# Altium schematic sheet ADC.SchDoc(ADC)
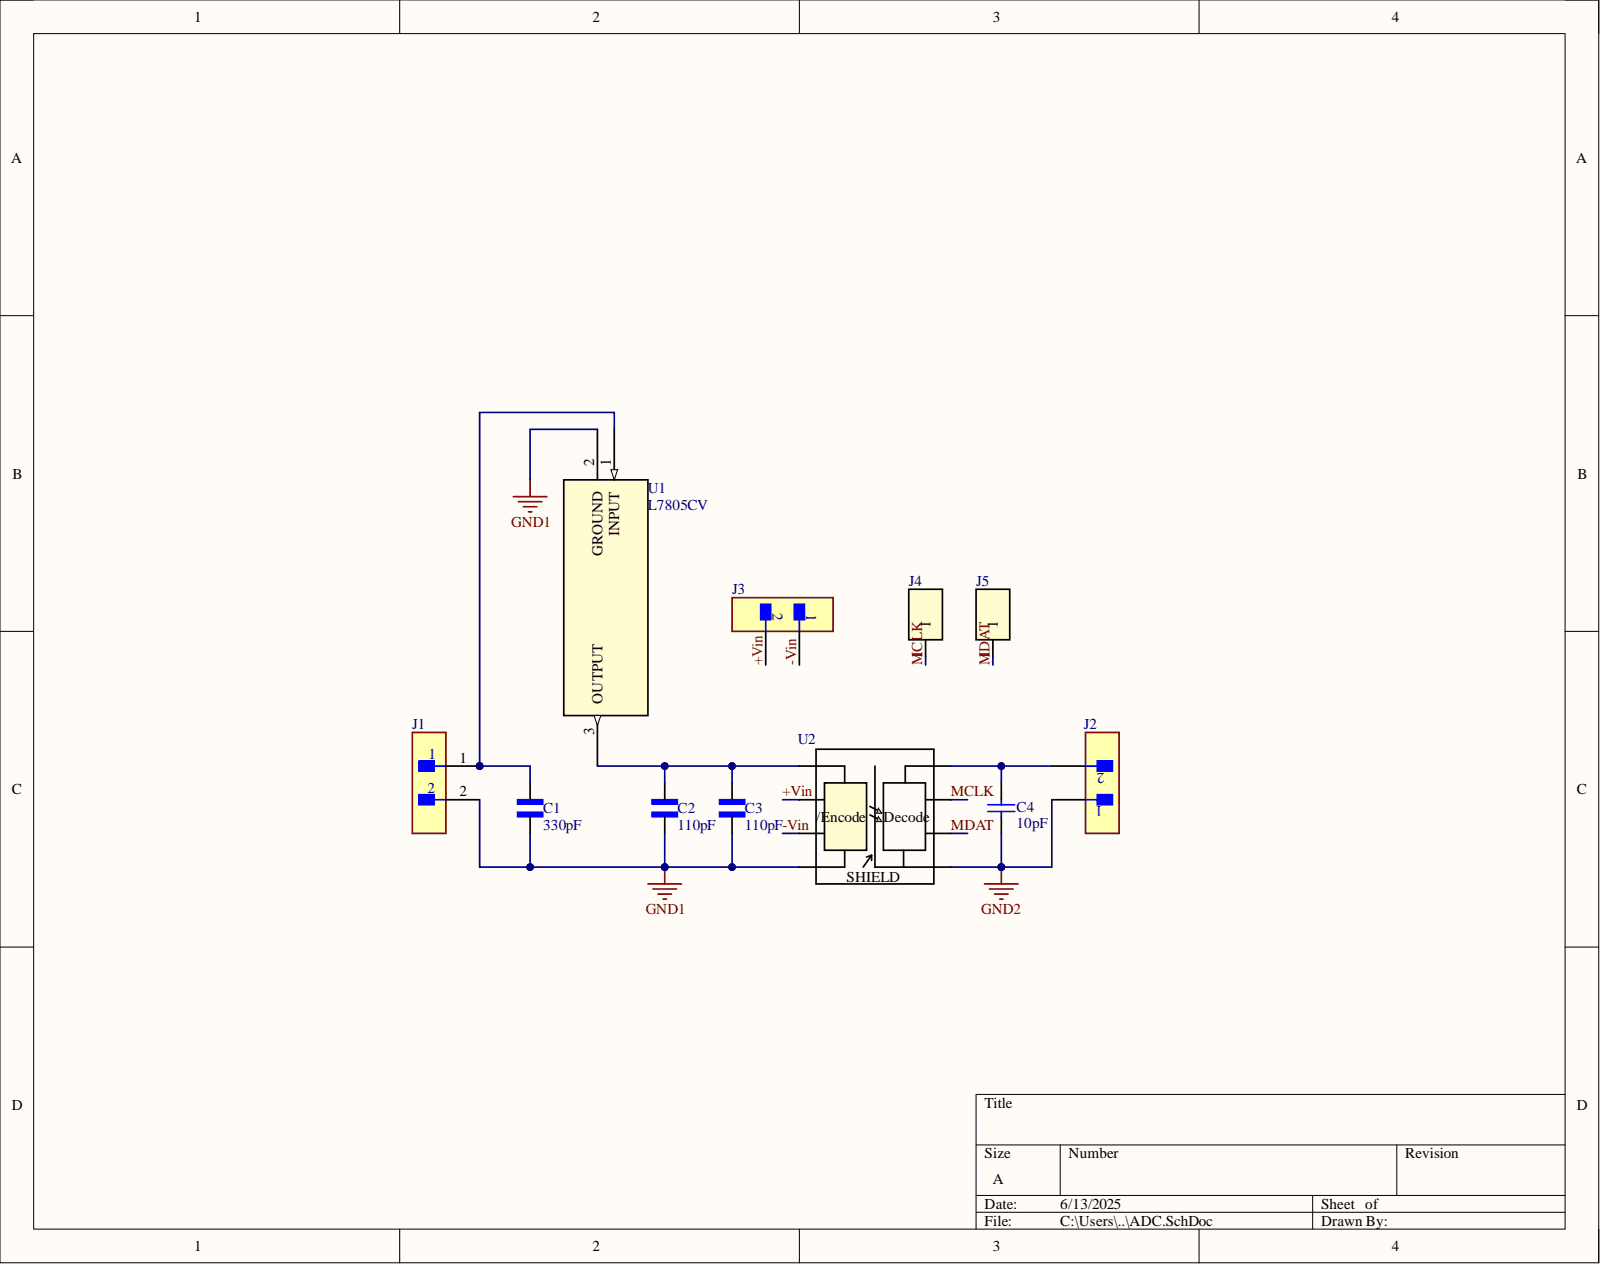
[diagram p0: ADC.SchDoc]

## Parts
C1  330pF  [CAP_ECHU1H331JX5]
C2  110pF  [CAP_ECHU1H101JX5]
C3  110pF  [CAP_ECHU1H101JX5]
C4  10pF  [CAPC0603X28X15LL05T05]
J1  M20-9990246  [FP-M20-9990246-MFG]  (value not drawn)
J2  M20-9990246  [FP-M20-9990246-MFG]  (value not drawn)
J3  M20-9990246  [FP-M20-9990246-MFG]  (value not drawn)
J4  87224-1  [87224-1_TYC]  (value not drawn)
J5  87224-1  [87224-1_TYC]  (value not drawn)
U1  L7805CV  [TO-220_STM]
U2  TLP7830TP4,E  [SO8L_TOS]  (value not drawn)

## Nets
GND1: C1.1 C2.1 C3.1 J1.2 U1.2 U2.4
GND2: C4.1 J2.2 U2.5
+Vin: J3.2 U2.2
-Vin: J3.1 U2.3
MCLK: J4.1 U2.7
MDAT: J5.1 U2.6
NetC1_2: C1.2 J1.1 U1.1
NetC2_2: C2.2 C3.2 U1.3 U2.1
NetC4_2: C4.2 J2.1 U2.8

## Library data of the parts
C1 = ECHU1H331JX5: Capacitor; ECHU, SMD, Stack Met PPS Film Cap, 50VDC, 0.00033uF, 5%, 0820, Cut Tape; Manufacturer: Panasonic; Manufacturer Part Number: ECH-U1H331JX5; Dielectric Material: Polyphenylene; Insulation Resistance: 3GR; Material: Ceramic; Voltage: 50V; Capacitance: 330pF; Case Code (Imperial): 0805; Case Code (Metric): 2012; Case/Package: 0805; Category: Film Capacitors; Check_prices: https://www.snapeda.com/parts/ECH-U1H331JX5/Panasonic/view-part/?ref=eda; Component Kind: Standard; ComponentLink1Description: https://datasheet.ciiva.com/11790/0900766b80eaa63b-11790633.pdf?src-supplier=Rs; ComponentLink1URL: https://datasheet.ciiva.com/11790/0900766b80eaa63b-11790633.pdf?src-supplier=Rs; ComponentLink2Description: https://datasheet.ciiva.com/2993/abd0000ce13-2993703.pdf?src-supplier=Avnet; ComponentLink2URL: https://datasheet.ciiva.com/2993/abd0000ce13-2993703.pdf?src-supplier=Avnet; ComponentLink3Description: https://datasheet.ciiva.com/14601/70067901-14601966.pdf?src-supplier=Allied+Electronics; ComponentLink3URL: https://datasheet.ciiva.com/14601/70067901-14601966.pdf?src-supplier=Allied+Electronics; ComponentLink4Description: https://datasheet.ciiva.com/14604/70067901-14604266.pdf?src-supplier=Allied+Electronics; ComponentLink4URL: https://datasheet.ciiva.com/14604/70067901-14604266.pdf?src-supplier=Allied+Electronics; ComponentLink5Description: https://datasheet.ciiva.com/29197/640786-da-01-en-smd-folien-kond-0805-330-0pf-50v-5-700st-29197909.pdf?src-supplier=Conrad; ComponentLink5URL: https://datasheet.ciiva.com/29197/640786-da-01-en-smd-folien-kond-0805-330-0pf-50v-5-700st-29197909.pdf?src-supplier=Conrad; Construction: Flat; Depth: 1.25mm; Dielectric: PPS; Digi-Key_Part_Number: PCF1322CT-ND; Height: 0.9mm; Lead Free: Lead Free; Length: 2mm; Library Name: Schlib1.SchLib; Max Operating Temperature: 125°C; MF: Panasonic; Min Operating Temperature: -55°C; Mount: Surface Mount,PCB; MP: ECH-U1H331JX5; Package: 0805-2 Panasonic; Package Quantity: 3000; Packaging: Tape and Reel; Pricing 1: 1=0.47, 10=0.308, 50=0.2392, 100=0.2167, 500=0.17598, 1000=0.16229 (USD); Purchase-URL: https://pricing.snapeda.com/search/part/ECH-U1H331JX5/?ref=eda; Radiation Hardening: No; RoHS: Yes; RoHS Compliant: Yes; Series: ECHU(X); SnapEDA_Link: https://www.snapeda.com/parts/ECH-U1H331JX5/Panasonic/view-part/?ref=snap; Stock 1: 10734; Supplier 1: Digikey; Supplier Part Number 1: PCF1322CT-ND; Termination: SMD/SMT; Tolerance: 5%; Voltage Rating (DC): 50V; Width: 1.25mm
C2 C3 = ECHU1H101JX5: Film Capacitor, 100 pF, 30 V, 50 V, ï¿½ 5%, Metallized PPS Stacked, 0805 [2012 Metric]; Manufacturer: Panasonic; Manufacturer Part Number: ECH-U1H101JX5; Capacitance: 100pF; Dielectric Material: Polyphenylene; Dissipation Factor: 0.6%; Insulation Resistance: 3GR; Material: Ceramic; Voltage: 50V; Case Code (Imperial): 0805; Case Code (Metric): 2012; Case/Package: 0805; Category: Film Capacitors; Check_prices: https://www.snapeda.com/parts/ECHU1H101JX5/Panasonic/view-part/?ref=eda; Component Kind: Standard; ComponentLink1Description: https://datasheet.ciiva.com/11790/0900766b80eaa63b-11790675.pdf?src-supplier=Rs; ComponentLink1URL: https://datasheet.ciiva.com/11790/0900766b80eaa63b-11790675.pdf?src-supplier=Rs; ComponentLink2Description: https://datasheet.ciiva.com/15899/abd0000ce13-15899628.pdf?src-supplier=Onlinecomponents.com; ComponentLink2URL: https://datasheet.ciiva.com/15899/abd0000ce13-15899628.pdf?src-supplier=Onlinecomponents.com; ComponentLink3Description: https://datasheet.ciiva.com/16388/abd0000ce13-16388712.pdf?src-supplier=Future+Electronics; ComponentLink3URL: https://datasheet.ciiva.com/16388/abd0000ce13-16388712.pdf?src-supplier=Future+Electronics; ComponentLink4Description: https://datasheet.ciiva.com/14593/70067901-14593175.pdf?src-supplier=Allied+Electronics; ComponentLink4URL: https://datasheet.ciiva.com/14593/70067901-14593175.pdf?src-supplier=Allied+Electronics; ComponentLink5Description: https://datasheet.ciiva.com/15163/getdatasheetpartid-118020-15163709.pdf?src-supplier=Verical; ComponentLink5URL: https://datasheet.ciiva.com/15163/getdatasheetpartid-118020-15163709.pdf?src-supplier=Verical; ComponentLink6Description: https://datasheet.ciiva.com/30154/21abd0000ce13-30154972.pdf?src-supplier=Onlinecomponents.com; ComponentLink6URL: https://datasheet.ciiva.com/30154/21abd0000ce13-30154972.pdf?src-supplier=Onlinecomponents.com; ComponentLink7Description: https://datasheet.ciiva.com/29198/640761-da-01-en-smd-folien-kond-0805-100-0pf-50v-5-700st-29198011.pdf?src-supplier=Conrad; ComponentLink7URL: https://datasheet.ciiva.com/29198/640761-da-01-en-smd-folien-kond-0805-100-0pf-50v-5-700st-29198011.pdf?src-supplier=Conrad; Construction: Flat; Depth: 1.25mm; Dielectric: PPS; Digi-Key_Part_Number: PCF1316TR-ND; Height: 0.9mm; Height - Seated (Max): 0.043inch; Lead Free: Lead Free; Length: 2mm; Library Name: Schlib1.SchLib; Max Operating Temperature: 125°C; MF: Panasonic; Min Operating Temperature: -55°C; Mount: Surface Mount,PCB; MP: ECHU1H101JX5; Number of Pins: 2; Package: 0805-2 Panasonic; Package Quantity: 1; Packaging: Tape and Reel; Pricing 1: 1=0.47, 10=0.242, 50=0.242, 100=0.22, 500=0.178, 1000=0.165, 3000=0.122, 9000=0.117, 10000=0.117, 24000=0.115, 45000=0.11 (USD); Radiation Hardening: No; Reach SVHC Compliant: No; RoHS: Yes; RoHS Compliant: Yes; Series: ECHU(X); SnapEDA_Link: https://www.snapeda.com/parts/ECHU1H101JX5/Panasonic/view-part/?ref=snap; Stock 1: 9424; Supplier 1: Mouser; Supplier Part Number 1: 667-ECH-U1H101JX5; Termination: SMD/SMT; Tolerance: 5%; Voltage Rating: 50V; Voltage Rating (AC): 50V; Voltage Rating (DC): 50V; Width: 1.25mm
C4 = CMP-2010-00276-1: Manufacturer: AVX Interconnect / Elco; Part Number: 0201ZK100GBSTR; Component Kind: Standard; Library Name: Altium Content Vault
J1-J3 = CMP-15831-000009-1: CONN HEADER VERT 2POS 2.54MM; Manufacturer: Harwin; Conn Gender: Male; Conn Orientation: Vertical; Current Rating: 3A; Number Of Contacts: 2; Automotive: No; Category: Conn; Component Kind: Standard; ComponentLink2Description: Datasheet; ComponentLink2URL: https://cdn.harwin.com/pdfs/M20-999.pdf; Contact Material: Tin,Nickel; Device Class L1: Connectors; Device Class L2: Headers and Wire Housings; Device Class L3: unset; Digikey Description: CONN HEADER VERT 2POS 2.54MM; Height: 8.99mm; Lead Free: Yes; Library Name: Altium Content Vault; Manufacturer Part Number: M20-9990246; Mouser Description: 2.54mm (0.1") Pitch SIL Vertical PC Tail Pin Header, 6.1mm mating pin height, tin, 2 contacts; Number Of Rows: 1; Octopart: https://octopart.com/m20-9990246-harwin-2590635; Package: M20-9990246; Pitch: 2.54mm; RoHS: TRUE; Temperature: -40°C to +105°C; Temperature Range High: +105°C; Temperature Range Low: -40°C
J4 J5 = 87224-1: PCB Header, Shrouded, 01 Unshrouded Straight Header .101, AMPMODU Mod II Series; Manufacturer: TE Connectivity; Approvals: CSA; Board Standoff: No (Without); Connector Type: Plug,Header,Pin; Contact Current Rating: 3A; Contact Post Length: 0.125inch; Gender: Male; High Speed Serial Data Connector: No; Number of Contacts: 1; PCB Mount Retention: Without; PCB Mounting Orientation: Vertical; PCB Thickness: 1.397mm; Pitch: 2.54mm; Voltage Rating: 750V; Category: Connectors; Component Kind: Standard; ComponentLink1Description: https://datasheet.ciiva.com/19461/840923-19461552.pdf?src-supplier=Jameco; ComponentLink1URL: https://datasheet.ciiva.com/19461/840923-19461552.pdf?src-supplier=Jameco; ComponentLink2Description: https://datasheet.ciiva.com/709/eng-cd-87224-l3-709782.pdf?src-supplier=Digikey; ComponentLink2URL: https://datasheet.ciiva.com/709/eng-cd-87224-l3-709782.pdf?src-supplier=Digikey; ComponentLink3Description: https://datasheet.ciiva.com/3118/eng-cs-1307819-section-5-0908-3118582.pdf?src-supplier=Avnet; ComponentLink3URL: https://datasheet.ciiva.com/3118/eng-cs-1307819-section-5-0908-3118582.pdf?src-supplier=Avnet; ComponentLink4Description: https://datasheet.ciiva.com/30252/eng-ds-1307819-ampmodu-0811-30252206.pdf?src-supplier=Onlinecomponents.com; ComponentLink4URL: https://datasheet.ciiva.com/30252/eng-ds-1307819-ampmodu-0811-30252206.pdf?src-supplier=Onlinecomponents.com; Contact Finish - Mating: GOLD; Contact Gender: Male; Contact Material: Bronze; Contact Mating Length: 8.08mm; Contact Plating: Gold; Contact Protection: No (Without); Contact Shape: Square; Contact Type: Male Pin; Current Rating: 3A; Depth: 2.54mm; ELV: Compliant; Fastening Type: Pull; Flammability Rating: UL94 V-0; Gasket: No (Without); Height: 10.37mm; High Temperature Housing: No; Housing Color: Black; Housing Material: Thermoplastic; Insulation Color: Black; Insulation Material: Thermoplastic; Keyed: No; Lead Free: Lead Free; Length: 14.83mm; Library Name: Schlib1.SchLib; Manufacturer Part Number: 87224-1; Mating Alignment: No (Without); Mating Connector Lock: No (Without); Max Operating Temperature: 105°C; Mfr_Name: TE Connectivity; Min Operating Temperature: -65°C; Mount: Through Hole; Number of Positions: 1; Number of Rows: 1; Orientation: Straight; Package Quantity: 520; Packaging: Carton; Pricing 1: 1=0.63, 10=0.538, 100=0.4572, 520=0.40694, 1040=0.3875, 5200=0.3459, 10400=0.3294 (USD); Reach SVHC Compliant: Unknown; RefDes: RefDes; RoHS: Yes; RoHS Compliant: Yes; Row Spacing: 2.54mm; Selectively Loaded: No (Without); Series: AMPMODU MOD II; Stabilizers: No (Without); Stock 1: 19978; Strain Relief: No (Without); Supplier 1: Digikey; Supplier Part Number 1: A26541-ND; Termination: Through Hole; Type: Type
U1 = L7805CV: Linear Voltage Regulator, Fixed, Positive Output, 35 V, 5 V, 1.5 A, 3 Pins, TO-220; Manufacturer: STMicroelectronics; Dropout Voltage: 2V; Max Input Voltage: 35V; Max Output Current: 1.5A; Min Input Voltage: 7V; Min Output Voltage: 4.65V; Nominal Output Voltage: 5V; Number of Channels: 1; Power Supply Rejection Ratio (PSRR): 62dB; Quiescent Current: 8mA; Tolerance: 5%; Case/Package: TO-220; Category: Voltage Regulators - Linear; Component Kind: Standard; ComponentLink1Description: https://datasheet.ciiva.com/29156/156048-da-01-en-spannungsregler-l-7805-cv-to220-stm-29156924.pdf?src-supplier=Conrad; ComponentLink1URL: https://datasheet.ciiva.com/29156/156048-da-01-en-spannungsregler-l-7805-cv-to220-stm-29156924.pdf?src-supplier=Conrad; ComponentLink2Description: https://datasheet.ciiva.com/6884/1805459-6884463.pdf?src-supplier=Newark; ComponentLink2URL: https://datasheet.ciiva.com/6884/1805459-6884463.pdf?src-supplier=Newark; ComponentLink3Description: https://datasheet.ciiva.com/2073/cd00000444-2073087.pdf?src-supplier=Digikey; ComponentLink3URL: https://datasheet.ciiva.com/2073/cd00000444-2073087.pdf?src-supplier=Digikey; ComponentLink4Description: https://datasheet.ciiva.com/16458/cd00000444-16458159.pdf?src-supplier=Future+Electronics; ComponentLink4URL: https://datasheet.ciiva.com/16458/cd00000444-16458159.pdf?src-supplier=Future+Electronics; Contact Plating: Gold; Height: 9.15mm; Lead Free: Lead Free; Length: 10.4mm; Library Name: Schlib1.SchLib; Manufacturer Part Number: L7805CV; Max Operating Temperature: 125°C; Mfr_Name: STMicroelectronics; Min Operating Temperature: -40°C; Mount: Through Hole; Number of Outputs: 1; Number of Pins: 3; Number of Regulators: 1; Output Current: 1.5A; Output Type: Fixed; Output Voltage: 5V; Polarity: Positive; Pricing 1: 1=0.53, 10=0.375, 50=0.3118, 100=0.2922, 250=0.27136, 500=0.2588, 1000=0.24847, 2500=0.23757, 5000=0.23099 (USD); Radiation Hardening: No; Reach SVHC Compliant: No; RefDes: RefDes; RoHS: No; Stock 1: 39349; Supplier 1: Digikey; Supplier Part Number 1: 497-1443-5-ND; Type: Type; Weight: 2.299997g; Width: 4.6mm
U2 = TLP7830TP4,E: Isolation Amplifier Single 5000Vrms 5V 8-Pin SOIC T/R; Manufacturer: Toshiba; Manufacturer Part Number: TLP7830(TP4,E; Mfr_Name: Toshiba Electronic Devices & Storage Corp.; Number_devices_in_Package: 1; Category: Linear ICs; Component Kind: Standard; Library Name: Schlib1.SchLib; Pricing 1: 1=6.34, 10=4.768, 100=3.8437, 500=3.519 (USD); RefDes: Refdes; RoHS: Yes; RoHS Compliant: Yes; Source_Package: TLP7830; Stock 1: 1205; Supplier 1: Digikey; Supplier Part Number 1: TLP7830(TP4ECT-ND; Type: Type
